# Altium schematic sheet Cnt.SchDoc
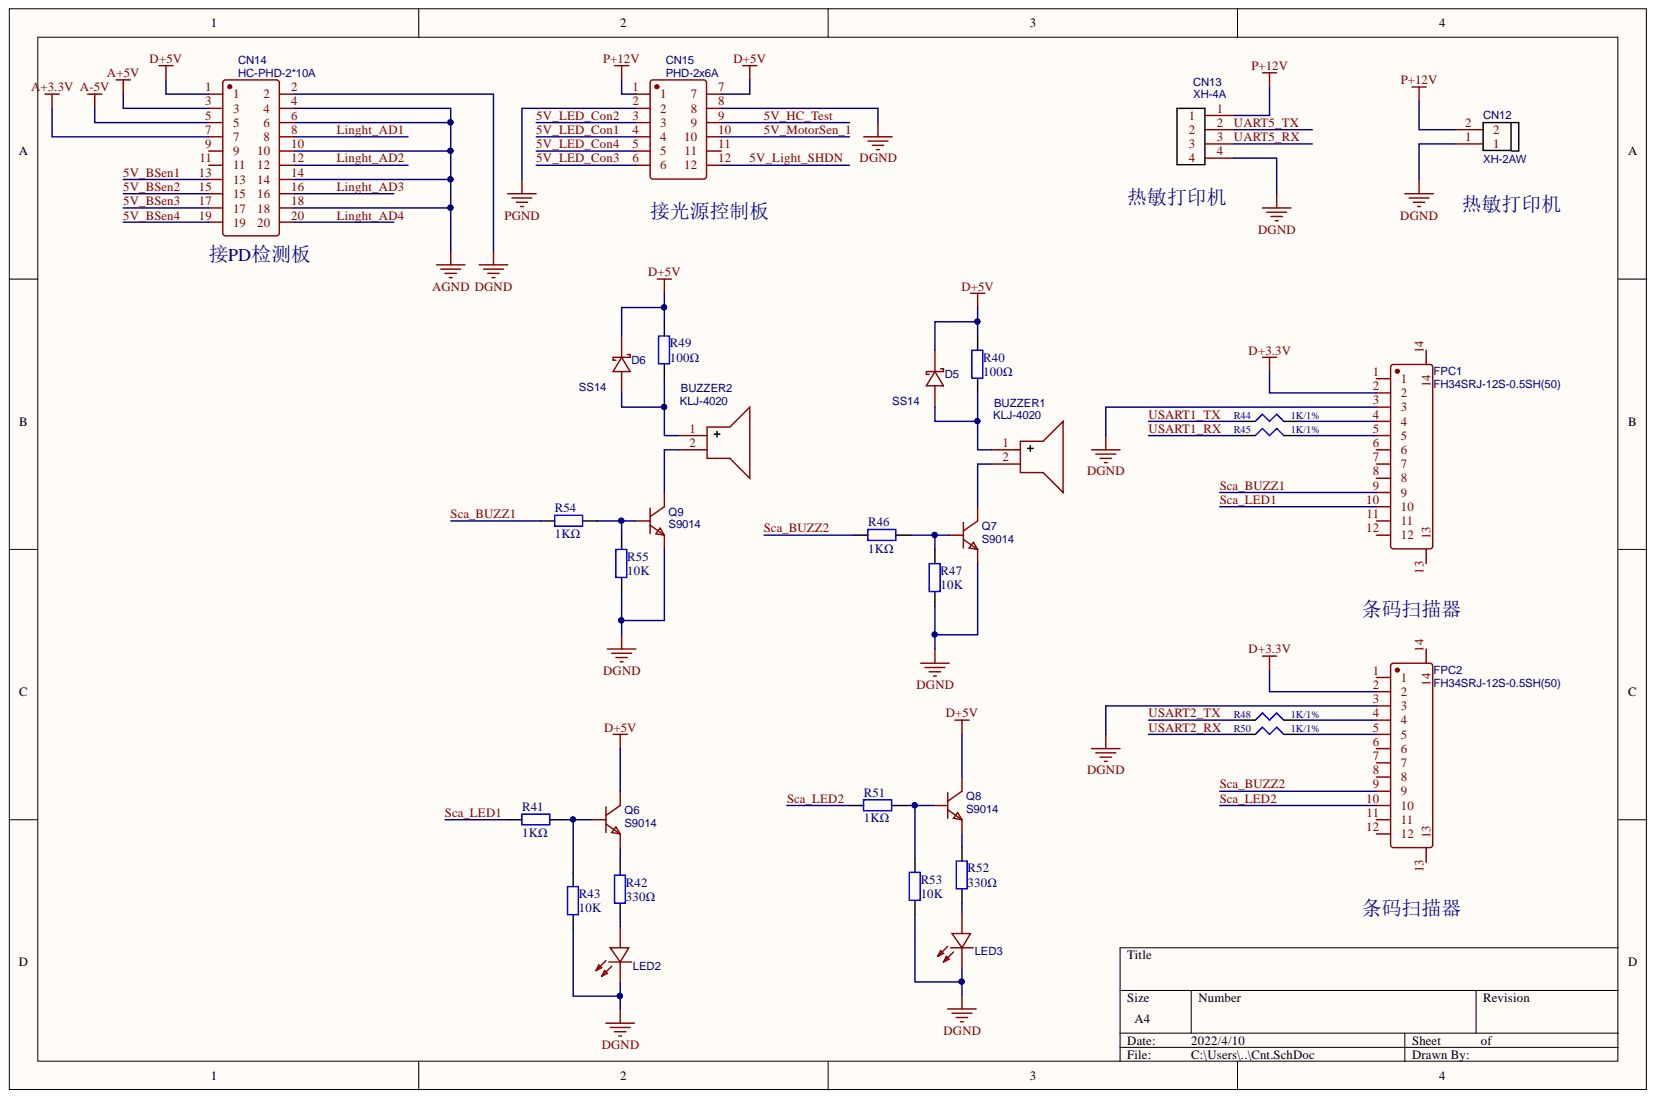
[diagram p0: Cnt.SchDoc]

## Parts
BUZZER1  KLJ-4020  [蜂鸣器]
BUZZER2  KLJ-4020  [蜂鸣器]
CN12  XH-2AW  [XH-2P]
CN13  XH-4A  [XH-4P]
CN14  HC-PHD-2*10A  [HC-PHD-2*10A]
CN15  PHD-2x6A  [PHD-2*6A]
D5  SS14  [SMA]
D6  SS14  [SMA]
FPC1  FH34SRJ-12S-0.5SH(50)  [FPC12P*0.5mm]
FPC2  FH34SRJ-12S-0.5SH(50)  [FPC12P*0.5mm]
LED2  LTST-C191TGKT  [LED0603]  (value not drawn)
LED3  LTST-C191TGKT  [LED0603]  (value not drawn)
Q6  S9014  [SOT-23]
Q7  S9014  [SOT-23]
Q8  S9014  [SOT-23]
Q9  S9014  [SOT-23]
R40  100Ω  [R0603]
R41  1KΩ  [R0603]
R42  330Ω  [R0603]
R43  10K  [R0603]
R44  1K/1%  [R0603]
R45  1K/1%  [R0603]
R46  1KΩ  [R0603]
R47  10K  [R0603]
R48  1K/1%  [R0603]
R49  100Ω  [R0603]
R50  1K/1%  [R0603]
R51  1KΩ  [R0603]
R52  330Ω  [R0603]
R53  10K  [R0603]
R54  1KΩ  [R0603]
R55  10K  [R0603]

## Nets
A+3.3V: CN14.7
A+5V: CN14.3
A-5V: CN14.5
AGND: CN14.4 CN14.6 CN14.10 CN14.14 CN14.18
D+3.3V: FPC1.2 FPC2.2
D+5V: CN14.1 CN15.7 D5.1 D6.1 Q6.3 Q8.3 R40.2 R49.2
DGND: CN12.1 CN13.4 CN14.2 CN15.8 FPC1.3 FPC2.3 LED2.1 LED3.1 Q7.2 Q9.2 R43.1 R47.1 R53.1 R55.1
P+12V: CN12.2 CN13.1 CN15.1
PGND: CN15.2
5V_BSen1: CN14.13
5V_BSen2: CN14.15
5V_BSen3: CN14.17
5V_BSen4: CN14.19
5V_HC_Test: CN15.9
5V_LED_Con1: CN15.4
5V_LED_Con2: CN15.3
5V_LED_Con3: CN15.6
5V_LED_Con4: CN15.5
5V_Light_SHDN: CN15.12
5V_MotorSen_1: CN15.10
Linght_AD1: CN14.8
Linght_AD2: CN14.12
Linght_AD3: CN14.16
Linght_AD4: CN14.20
Sca_BUZZ1: FPC1.9 R54.2
Sca_BUZZ2: FPC2.9 R46.2
Sca_LED1: FPC1.10 R41.2
Sca_LED2: FPC2.10 R51.2
UART5_RX: CN13.3
UART5_TX: CN13.2
USART1_RX: R45.1
USART1_TX: R44.1
USART2_RX: R50.1
USART2_TX: R48.1
NetBUZZER1_1: BUZZER1.1 D5.2 R40.1
NetBUZZER1_2: BUZZER1.2 Q7.3
NetBUZZER2_1: BUZZER2.1 D6.2 R49.1
NetBUZZER2_2: BUZZER2.2 Q9.3
NetFPC1_4: FPC1.4 R44.2
NetFPC1_5: FPC1.5 R45.2
NetFPC2_4: FPC2.4 R48.2
NetFPC2_5: FPC2.5 R50.2
NetLED2_2: LED2.2 R42.1
NetLED3_2: LED3.2 R52.1
NetQ6_1: Q6.1 R41.1 R43.2
NetQ6_2: Q6.2 R42.2
NetQ7_1: Q7.1 R46.1 R47.2
NetQ8_1: Q8.1 R51.1 R53.2
NetQ8_2: Q8.2 R52.2
NetQ9_1: Q9.1 R54.1 R55.2

Not connected: CN14 9 11; CN15 11; FPC1 1 6 7 8 11 12 13 14; FPC2 1 6 7 8 11 12 13 14

## Library data of the parts
BUZZER1 BUZZER2 = KLJ-4020: BOM_Manufacturer: KELIKING; Contributor: LCSC; BOM_JLCPCB Part Class: Extended Part; BOM_Manufacturer Part: KLJ-4020; BOM_Supplier: LCSC; BOM_Supplier Part: C556936; Component Kind: Standard; spicePre: B; spiceSymbolName: KLJ-4020
CN12 = XH-2AW: BOM_Manufacturer: BOOMELE; BOM_JLCPCB Part Class: Extended Part; BOM_Manufacturer Part: XH-2AW; BOM_Supplier: LCSC; BOM_Supplier Part: C33132; Component Kind: Standard; spicePre: C; spiceSymbolName: XH-2AW
CN13 = XH-4A: BOM_Manufacturer: BOOMELE; BOM_JLCPCB Part Class: Extended Part; BOM_Manufacturer Part: XH-4A; BOM_Supplier: LCSC; BOM_Supplier Part: C37815; Component Kind: Standard; spicePre: C; spiceSymbolName: XH-4A
CN14 = HC-PHD-2*10A: BOM_Manufacturer: HCTL(华灿天禄); Contributor: LCSC; BOM_Manufacturer Part: HC-PHD-2*10A; BOM_Supplier: LCSC; BOM_Supplier Part: C2845621; Component Kind: Standard; spicePre: C; spiceSymbolName: HC-PHD-2*10A
CN15 = PHD-2x6A: BOM_Manufacturer: BOOMELE; BOM_Manufacturer Part: PHD-2*6P 2.0mmpitch; BOM_JLCPCB Part Class: Extended Part; BOM_Supplier: LCSC; BOM_Supplier Part: C58103; Component Kind: Standard; spicePre: X; spiceSymbolName: PHD-2x6A
D5 D6 = SS24AD: BOM_Manufacturer: SEMTECH; SMT Type: Extend; BOM_JLCPCB SMD: Yes; BOM_Manufacturer Part: SS24AD; BOM_Supplier: LCSC; BOM_Supplier Part: C84503; Component Kind: Standard; image: //image.lceda.cn/szlcsc/C84503.jpg; spicePre: D; spiceSymbolName: SS24AD
FPC1 FPC2 = FH34SRJ-12S-0.5SH(50): BOM_Manufacturer: Hirose; Contributor: LCSC; BOM_JLCPCB Part Class: Extended Part; BOM_Manufacturer Part: FH34SRJ-12S-0.5SH(50); BOM_Supplier: LCSC; BOM_Supplier Part: C424659; Component Kind: Standard; spicePre: F; spiceSymbolName: FH34SRJ-12S-0.5SH(50)
LED2 LED3 = LTST-C191TGKT: BOM_Manufacturer: LITEON; Contributor: LCSC; BOM_Manufacturer Part: LTST-C191TGKT; BOM_Supplier: LCSC; BOM_Supplier Part: C125101; Component Kind: Standard; spicePre: L; spiceSymbolName: LTST-C191TGKT
Q6-Q9 = S9014: BOM_Manufacturer: BORN伯恩半导体; Contributor: LCSC; BOM_JLCPCB Part Class: Extended Part; BOM_Manufacturer Part: S9014; BOM_Supplier: LCSC; BOM_Supplier Part: C920324; Component Kind: Standard; spicePre: Q; spiceSymbolName: S9014
R40-R43 R46 R47 R49 R51-R55 = 0603 1.5KΩ (1501) 1%: 贴片电阻; Component Kind: Standard; ComponentLink1Description: 供应商链接; ComponentLink1URL: http://www.szlcsc.com/product/details_23570.html; Library Name: RES.SchLib; Package: 0603; Supplier: LC; SuppliersPartNumber: C22843
R44 R45 R48 R50 = RES 0805: Component Kind: Standard; Library Name: C-LifeReal_1.SchLib
